# Altium schematic sheet FLASH_adapter.SchDoc(FLASH_adapter)
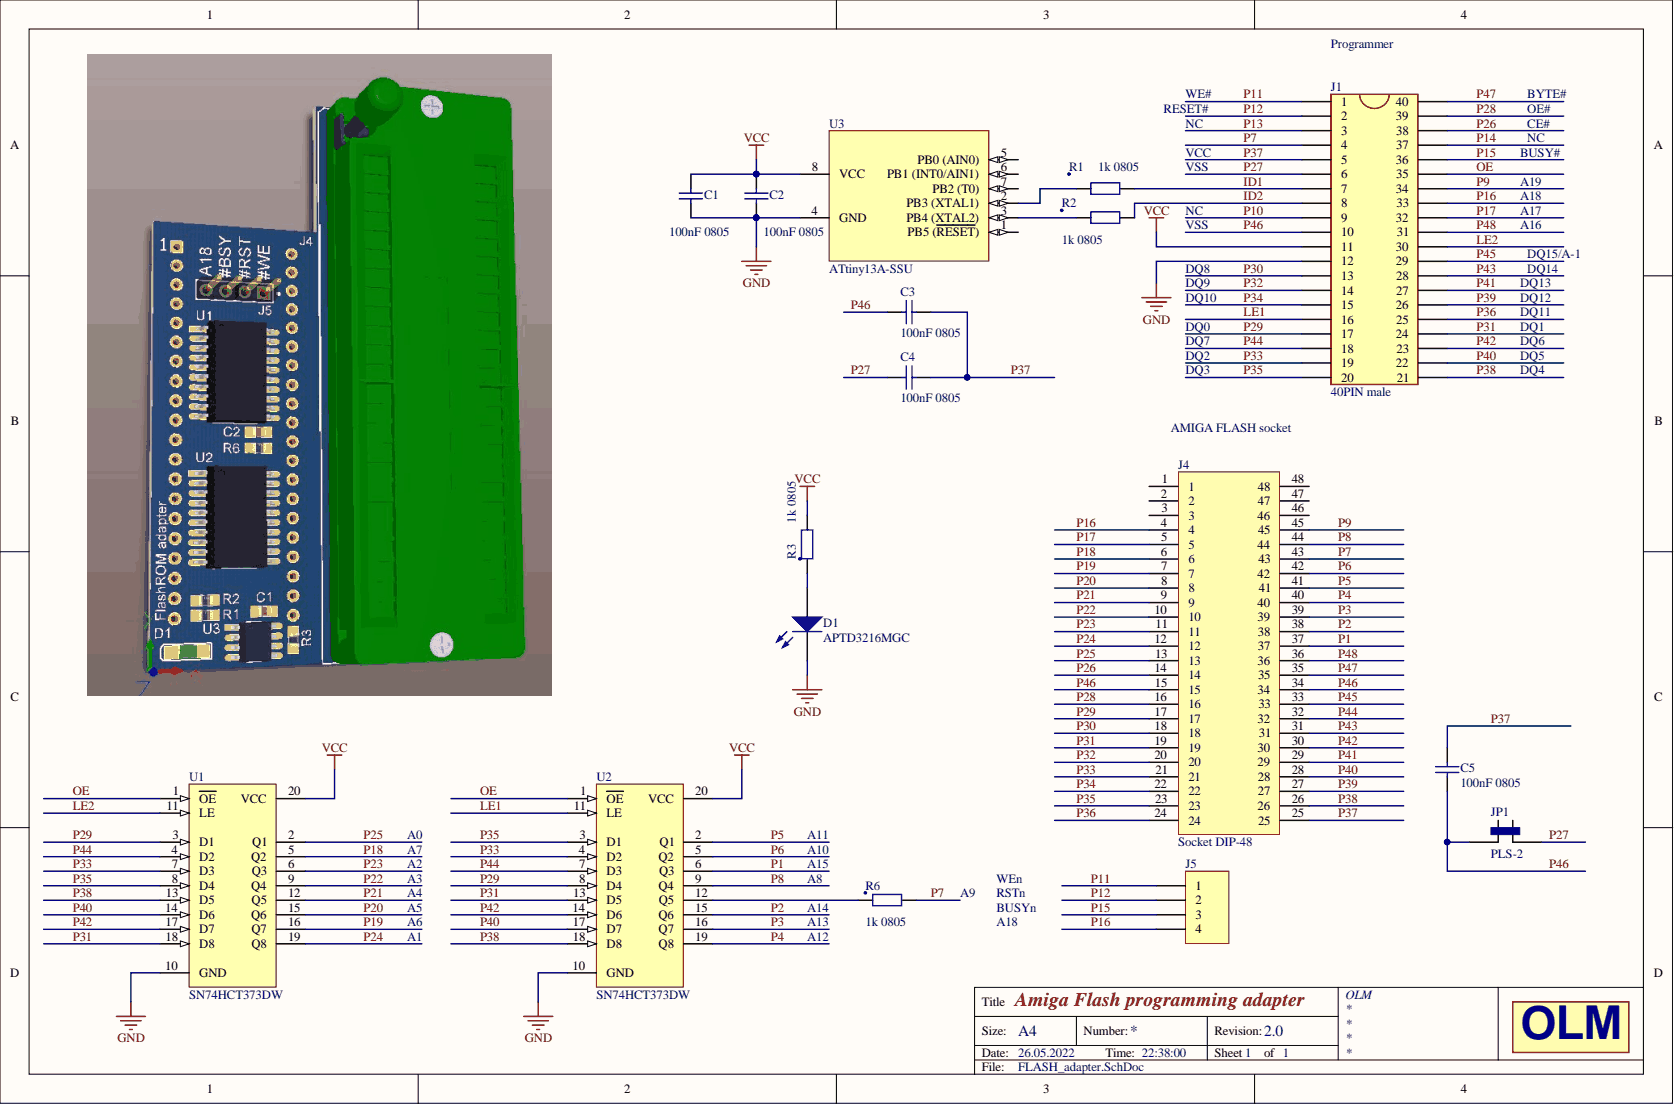
[diagram p0: FLASH_adapter.SchDoc]

## Parts
C1  value 100nF 0805  [SM/C_0805]  (hidden comment: Cap)
C2  value 100nF 0805  [SM/C_0805]  (hidden comment: Cap)
C3  value 100nF 0805  [SM/C_0805]  (hidden comment: Cap)
C4  value 100nF 0805  [SM/C_0805]  (hidden comment: Cap)
C5  value 100nF 0805  [SM/C_0805]  (hidden comment: Cap)
D1  value APTD3216MGC  [SM/LED_1206_Green]  (hidden comment: Светодиод)
J1  40PIN male  [Header DIP40_d0.9]
J4  Socket  value Socket DIP-48  [Socket DIP48 ZIF]
J5  Header 4  [PLS-4]  (value not drawn)
JP1  value PLS-2  [BLOB-2]  (hidden comment: Разъем)
R1  value 1k 0805  [SM/R_0805]  (hidden comment: Резистор)
R2  value 1k 0805  [SM/R_0805]  (hidden comment: Резистор)
R3  value 1k 0805  [SM/R_0805]  (hidden comment: Резистор)
R6  value 1k 0805  [SM/R_0805]  (hidden comment: Резистор)
U1  SN74HCT373DW  [SOG.050/20/WG.420/L.500]
U2  SN74HCT373DW  [SOG.050/20/WG.420/L.500]
U3  ATtiny13A-SSU  [SOG.050/8/WG.244/L.200]

## Nets
GND: C1.2 C2.2 D1.2 J1.12 U1.10(GND) U2.10(GND) U3.4(GND)
VCC: C1.1 C2.1 J1.11 R3.1 U1.20(VCC) U2.20(VCC) U3.8(VCC)
ID1: J1.7 R1.1
ID2: J1.8 R2.1
LE1: J1.16 U2.11(LE)
LE2: J1.30 U1.11(LE)
OE: J1.35 U1.1(OE) U2.1(OE)
P1: J4.37 U2.6(Q3)
P2: J4.38 U2.15(Q6)
P3: J4.39 U2.16(Q7)
P4: J4.40 U2.19(Q8)
P5: J4.41 U2.2(Q1)
P6: J4.42 U2.5(Q2)
P7: J1.4 J4.43 R6.1
P8: J4.44 U2.9(Q4)
P9: J1.34 J4.45
P10: J1.9
P11: J1.1 J5.1
P12: J1.2 J5.2
P13: J1.3
P14: J1.37
P15: J1.36 J5.3
P16: J1.33 J4.4 J5.4
P17: J1.32 J4.5
P18: J4.6 U1.5(Q2)
P19: J4.7 U1.16(Q7)
P20: J4.8 U1.15(Q6)
P21: J4.9 U1.12(Q5)
P22: J4.10 U1.9(Q4)
P23: J4.11 U1.6(Q3)
P24: J4.12 U1.19(Q8)
P25: J4.13 U1.2(Q1)
P26: J1.38 J4.14
P27: C4.2 J1.6 JP1.2
P28: J1.39 J4.16
P29: J1.17 J4.17 U1.3(D1) U2.8(D4)
P30: J1.13 J4.18
P31: J1.24 J4.19 U1.18(D8) U2.13(D5)
P32: J1.14 J4.20
P33: J1.19 J4.21 U1.7(D3) U2.4(D2)
P34: J1.15 J4.22
P35: J1.20 J4.23 U1.8(D4) U2.3(D1)
P36: J1.25 J4.24
P37: C3.1 C4.1 C5.1 J1.5 J4.25
P38: J1.21 J4.26 U1.13(D5) U2.18(D8)
P39: J1.26 J4.27
P40: J1.22 J4.28 U1.14(D6) U2.17(D7)
P41: J1.27 J4.29
P42: J1.23 J4.30 U1.17(D7) U2.14(D6)
P43: J1.28 J4.31
P44: J1.18 J4.32 U1.4(D2) U2.7(D3)
P45: J1.29 J4.33
P46: C3.2 C5.2 J1.10 J4.15 J4.34 JP1.1
P47: J1.40 J4.35
P48: J1.31 J4.36
NetD1_1: D1.1 R3.2
NetR1_2: R1.2 U3.2(PB3_(XTAL1))
NetR2_2: R2.2 U3.3(PB4_(XTAL2))
NetR6_2: R6.2 U2.12(Q5)

Not connected: J4 1 2 3 46 47 48; U3 1(PB5_(RESET)) 5(PB0_(AIN0)) 6 7(PB2_(T0))

## Library data of the parts
C1 C3 C4 = Cap ceramic: CAP-100Nf; Component Kind: Standard; Library Name: New.SCHLIB
C2 C5 = Cap ceramic: CAP-100Nf; Value: 100nF 0805; Component Kind: Standard; Library Name: New.SCHLIB
D1 = LED0: Typical INFRARED GaAs LED; Примечание: Kingbright; Component Kind: Standard; LatestRevisionDate: 08-Jul-2005; LatestRevisionNote: Mech Layer 1 removed.; Library Name: Miscellaneous Devices.IntLib; PackageDescription: LED; 2 Leads; PackageReference: LED-0; Published: 8-Jun-2000; Simulation: LED0; Publisher: Altium Limited
J1 = ZIF 40 pin: Component Kind: Standard; Library Name: New_home.SCHLIB
J4 = Socket 48: Component Kind: Standard; Library Name: Connectors_home.SchLib
J5 = Header 4: Header, 4-Pin; Component Kind: Standard; LatestRevisionDate: 17-Jul-2002; LatestRevisionNote: Re-released for DXP Platform.; Library Name: New_home.SCHLIB; Publisher: Altium Limited
JP1 = Jumper2: Jumper Wire; Manufacturer: Generic Components; Component Kind: Standard; Library Name: New_home.SCHLIB; Published: 1999
R1-R3 R6 = Res2: Resistor; Component Kind: Standard; LatestRevisionDate: 17-Jul-2002; LatestRevisionNote: Re-released for DXP Platform.; Library Name: Miscellaneous Devices.IntLib; PackageReference: AXIAL-0.4; Published: 8-Jun-2000; Signal Integrity: Res; Simulation: RESISTOR; Publisher: Altium Limited
U1 U2 = SN74AHCT373DW: Octal Transparent D-Type Latch with 3-State Outputs; Code_IPC: SOIC127P1030-20; Code_JEDEC: MS-013; Component Kind: Standard; ComponentLink1Description: Manufacturer Link; ComponentLink1URL: http://www.ti.com/; ComponentLink2Description: Datasheet; ComponentLink2URL: http://www-s.ti.com/sc/ds/sn74ahct373.pdf; DatasheetVersion: Oct-1998; LatestRevisionDate: 13-Apr-2006; LatestRevisionNote: IPC-7351 Footprint Added.; Library Name: TI Logic Latch.IntLib; PackageDescription: 20-Pin Plastic Small Outline Integrated Circuit 1.27 mm Pitch; PackageReference: DW020; PackageVersion: Mar-2000; PCB3D: DW020; Published: 8-Jun-2000; Signal Integrity: SN74AHCT373DW; Publisher: Altium Limited
U3 = ATtiny11-6PI: AVR 1K Byte of Flash Memory; Component Kind: Standard; ComponentLink1Description: Manufacturer Link; ComponentLink1URL: http://www.atmel.com; ComponentLink2Description: Datasheet; ComponentLink2URL: http://www.atmel.com/dyn/resources/prod_documents/doc1006.pdf; DatasheetVersion: 1006C-09/01; LatestRevisionDate: 04-Mar-2005; LatestRevisionNote: Stylized 3D Model Added.; Library Name: Mylib.IntLib; PackageDescription: DIP; 8 Leads; Row Spacing 7.62 mm; Pitch 2.54 mm; PackageReference: 8P3; PackageVersion: revB, Sep-2001; Published: 17-Sep-2003; Publisher: Altium Limited
